# Altium schematic sheet MotorDriver.Mikroe.SchDoc
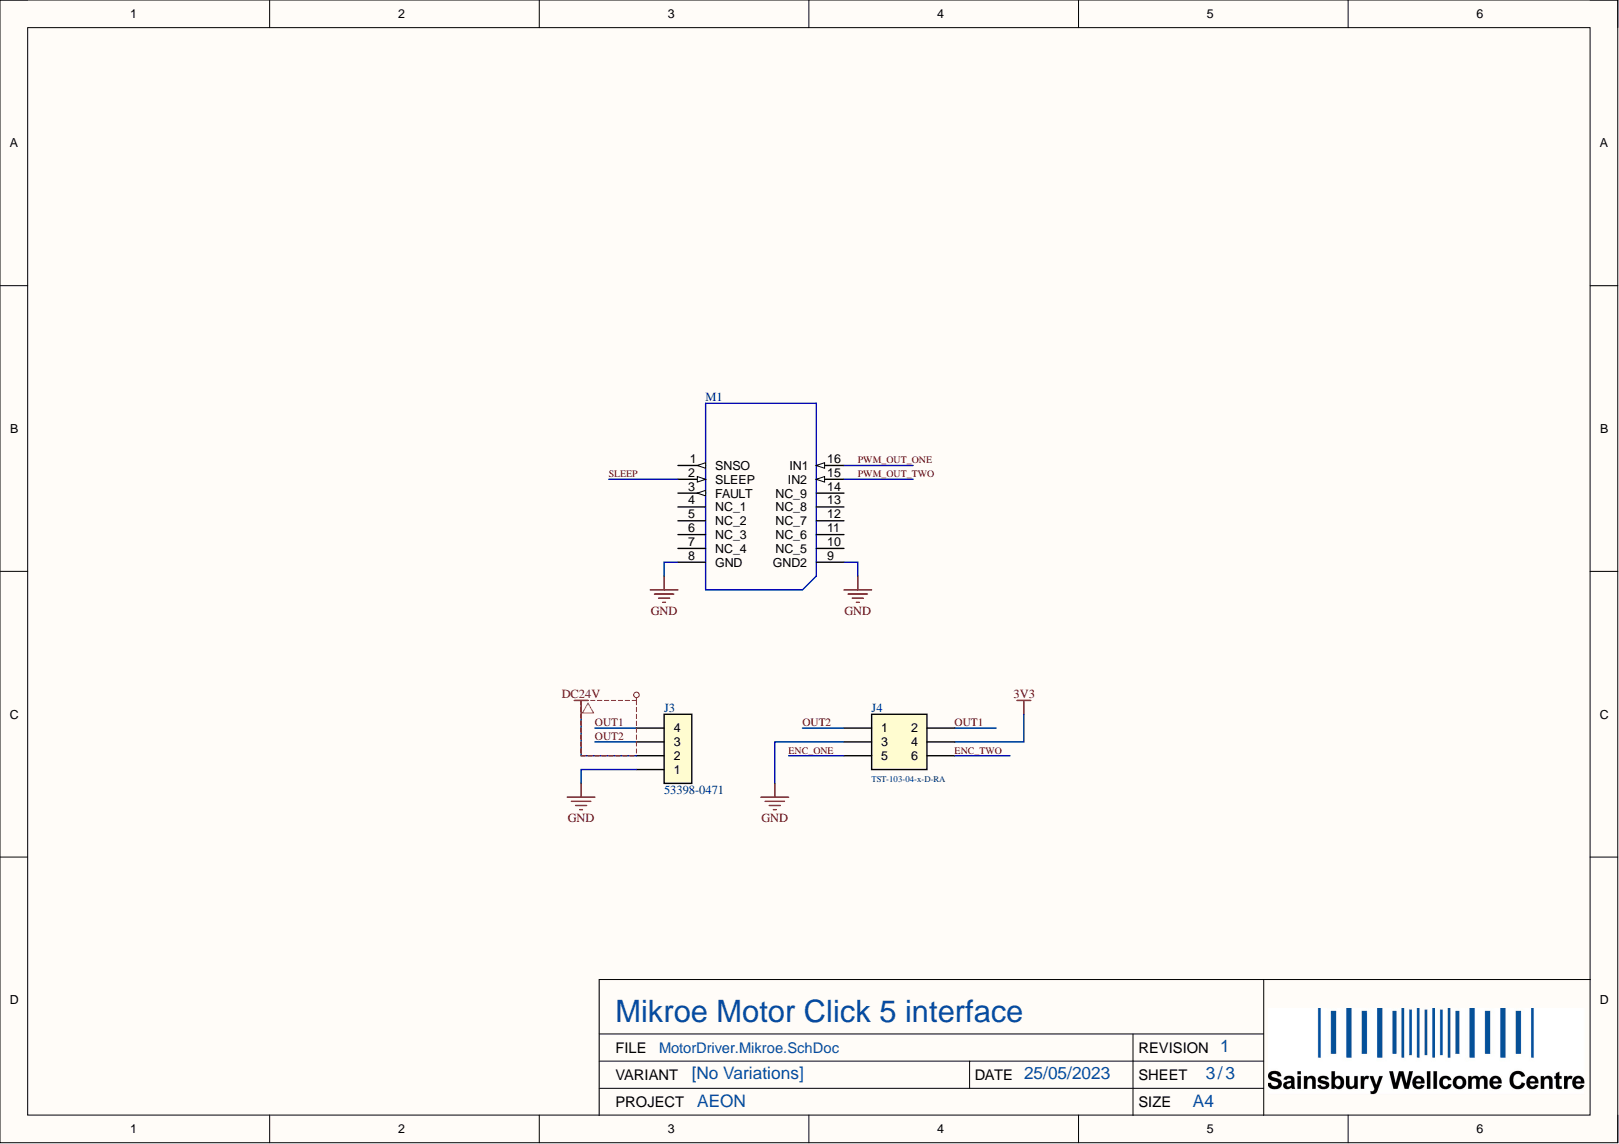
[diagram p0: MotorDriver.Mikroe.SchDoc]

## Parts
J3  53398-0471  [FP-533980471-MFG]
J4  TST-103-04-x-D-RA  [SAMTEC_TST-103-04-G-D-RA]
M1  MIKROE-2699  [MIKROE-M]  (value not drawn)

## Nets
3V3: J4.4
DC24V: J3.2
GND: J3.1 J4.3 M1.8(GND) M1.9(GND2)
~{SLEEP}: M1.2(SLEEP)
ENC_ONE: J4.5
ENC_TWO: J4.6
OUT1: J3.4 J4.2
OUT2: J3.3 J4.1
PWM_OUT_ONE: M1.16(IN1)
PWM_OUT_TWO: M1.15(IN2)

Not connected: M1 1(SNSO) 3(FAULT) 4(NC_1) 5(NC_2) 6(NC_3) 7(NC_4) 10(NC_5) 11(NC_6) 12(NC_7) 13(NC_8) 14(NC_9)

## Library data of the parts
J3 = CMP-370-0030-2: Board Connector, 4 Contact(s), 1 Row(s), Male, Straight, 0.049 inch Pitch, Surface Mount Terminal, Locking, Natural Insulator, Receptacle; Board Mounting Option: FITTING NAIL; Body Breadth: 0.146inch; Body Depth: 0.185inch; Body Length: 0.383inch; Contact Pattern: RECTANGULAR; Contact Resistance: 20mR; Contact Style: Blade Pin; Dielectric Withstanding Voltage (AC): 250V; DIN Conformance: No; Durability: 30Cycles; Insulation Resistance: 100MR; Mating Information: MULTIPLE MATING PARTS AVAILABLE; Number Of Connectors: 1; Number of Contacts: 4; Option: General Purpose; Plating Thickness: 39uin; Polarization Key: POLARIZED HOUSING; Rated Current (Signal): 1A; Reference Standard: UL; Reliability: COMMERCIAL; Body/Shell Style: RECEPTACLE; Component Kind: Standard; Connector Type: BOARD CONNECTOR; Contact Finish - Mating: MATTE TIN (39) OVER NICKEL; Contact Finish - Termination: Matte Tin (Sn) - with Nickel (Ni) barrier; Contact Gender: Male; Contact Material: Bronze; Filter Feature: No; Flammability Rating: UL94 V-0; IEC Conformance: No; Insulation Color: Natural; Insulation Material: Polyamide; JESD-609 Code: e3; Mating Contact Pitch: 0.049inch; Max Operating Temperature: 85°C; MIL Conformance: No; Min Operating Temperature: -40°C; Mixed Contacts: No; Mount: Board; Mounting Option 1: LOCKING; Mounting Style: STRAIGHT; Number Of PCB Rows: 1; Number of Rows Loaded: 1; PartNumber: 53398-0471; PCB Contact Pattern: RECTANGULAR; REACH SVHC: Yes; RoHS Compliant: Yes; Terminal Pitch: 1.25mm; Termination: SMD/SMT; Library Name: Sainsbury Wellcome Centre
J4 = CMP-370-0023-6: Board Connector, 6 Contact(s), 2 Row(s), Male, Right Angle, Solder Terminal; PartNumber: TST-103-04-G-D-RA; Number of Contacts: 6; Pitch: 2.54; Component Kind: Standard; Mount: Through Hole; Number of Rows: 2; Library Name: Sainsbury Wellcome Centre
M1 = CMP-A10-0003-3: DRV8701 Brushed DC Motor Full-Bridge Gate DC Motor Driver 5 Click Add-On Board; Component Kind: Standard; Library Name: Sainsbury Wellcome Centre
